# Altium schematic sheet urbanturbine.SchDoc(urbanturbine)
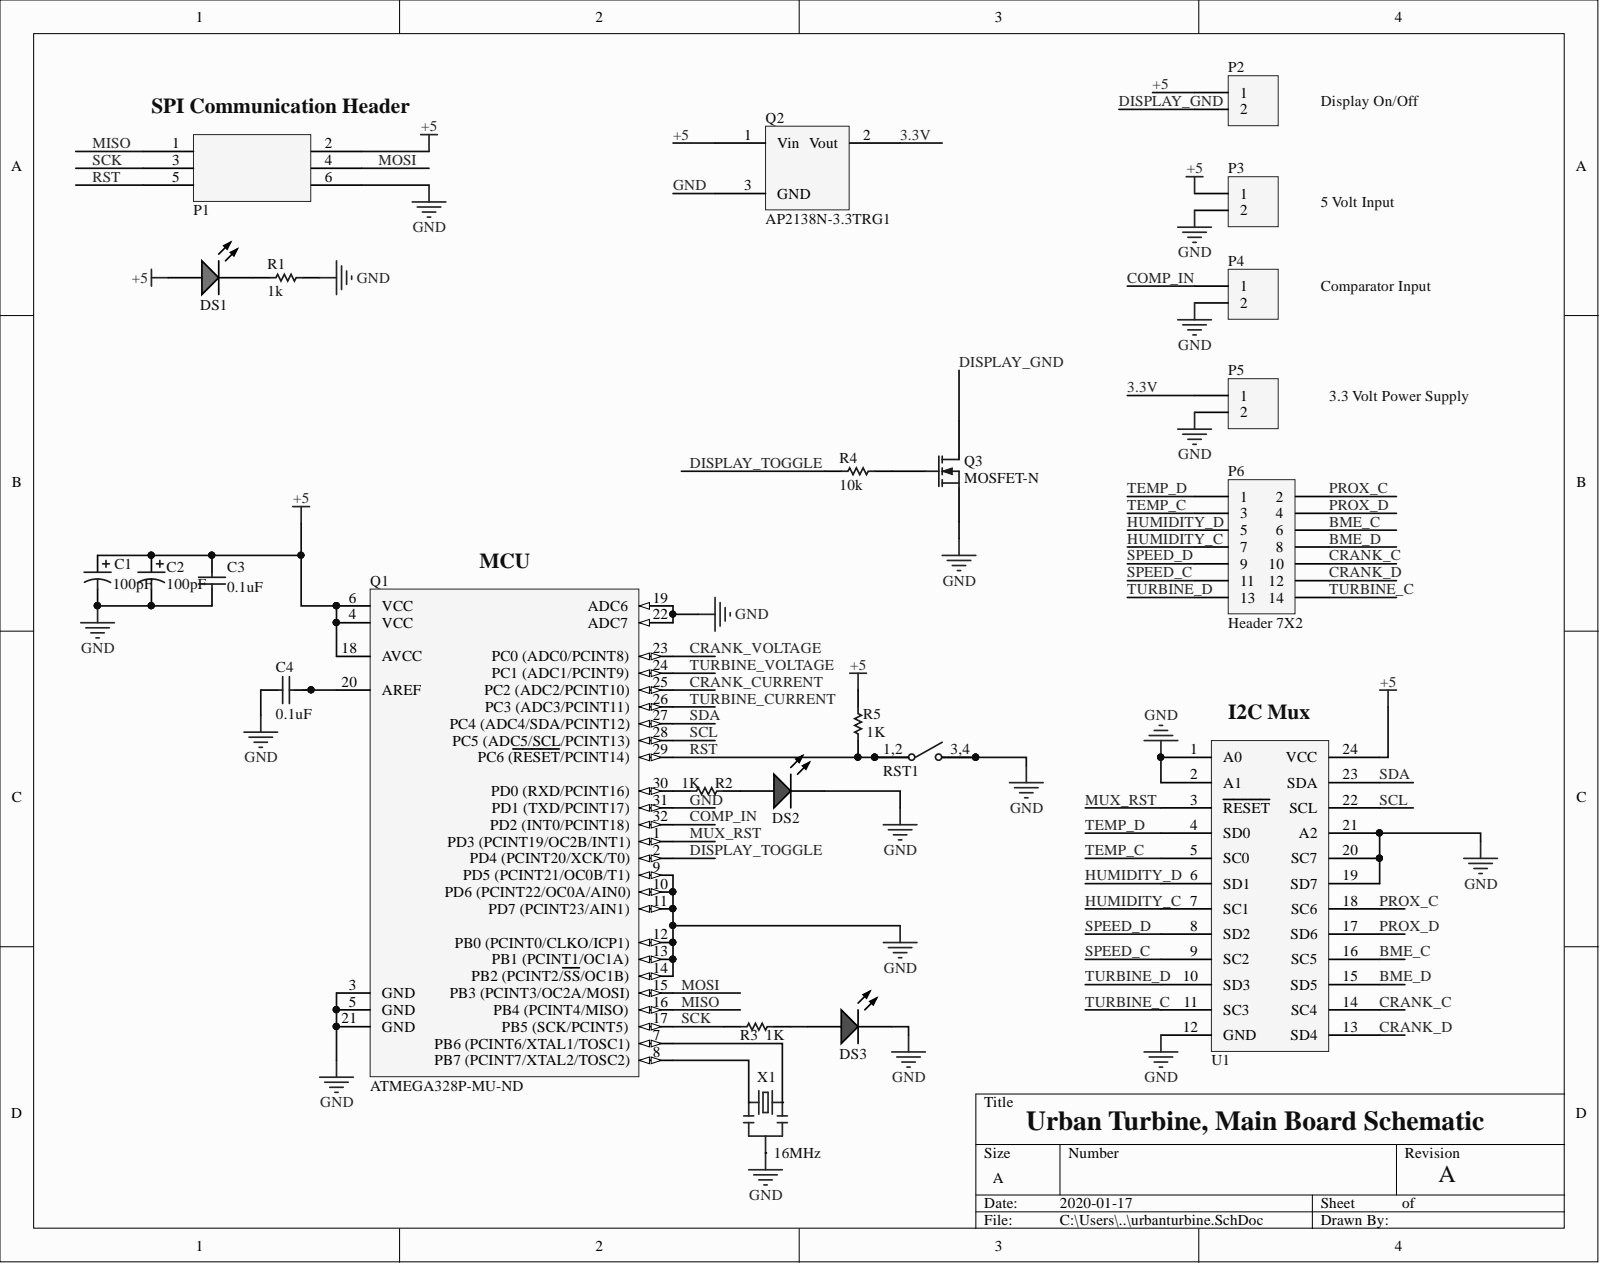
[diagram p0: urbanturbine.SchDoc]

## Parts
C1  value 100pF  [RAD-0.1]  (hidden comment: Cap Pol1)
C2  value 100pF  [RAD-0.1]  (hidden comment: Cap Pol1)
C3  value 0.1uF  [0402]  (hidden comment: C1005X7R1H104K050BB)
C4  value 0.1uF  [0402]  (hidden comment: C1005X7R1H104K050BB)
DS1  LTST-C191KGKT  [KING-LED0603-25-GREEN_V]  (value not drawn)
DS2  LTST-C191KRKT  [KING-LED0603-25-RED_V]  (value not drawn)
DS3  LTST-C191KRKT  [KING-LED0603-25-RED_V]  (value not drawn)
P1  M20-9980346  [2X3]  (value not drawn)
P2  (no value)  [HDR1X2]
P3  (no value)  [HDR1X2]
P4  (no value)  [HDR1X2]
P5  (no value)  [HDR1X2]
P6  Header 7X2  [HDR2X7]
Q1  ATMEGA328P-MU  Supplier Part Number 1: ATMEGA328P-MU-ND  [*DIL28]
Q2  AP2138N-3.3TRG1  [SOT23_M]
Q3  MOSFET-N  [E3]
R1  value 1k  [6-0805_M]  (hidden comment: RC0603JR-071KL)
R2  value 1K  [6-0805_M]  (hidden comment: Res1)
R3  value 1K  [6-0805_M]  (hidden comment: Res1)
R4  value 10k  [6-0805_M]  (hidden comment: ERJ-3EKF1002V)
R5  value 1K  [6-0805_M]  (hidden comment: Res1)
RST1  PTS645SH50SMTR92 LFS  [TACTILE SWITCH SMD]  (value not drawn)
U1  TCA9548A  [TCA9548A]  (value not drawn)
X1  16MHz  [RESONATOR-SMD]

## Nets
3.3V: P5.1 Q2.2
+5: C1.1 C2.1 C3.2 DS1.1 P1.2 P2.1 P3.1 Q1.4(VCC) Q1.6(VCC) Q1.18(AVCC) Q2.1 R5.1 U1.24(VCC)
BME_C: P6.6 U1.16(SC5)
BME_D: P6.8 U1.15(SD5)
COMP_IN: P4.1 Q1.32(PD2_(INT0/PCINT18))
CRANK_C: P6.10 U1.14(SC4)
CRANK_CURRENT: Q1.25
CRANK_D: P6.12 U1.13(SD4)
CRANK_VOLTAGE: Q1.23(PC0_(ADC0/PCINT8))
DISPLAY_GND: P2.2 Q3.1
DISPLAY_TOGGLE: Q1.2(PD4_(PCINT20/XCK/T0)) R4.2
GND: C1.2 C2.2 C3.1 C4.1 DS2.2 DS3.2 P1.6 P3.2 P4.2 P5.2 Q1.3(GND) Q1.5(GND) Q1.9(PD5_(PCINT21/OC0B/T1)) Q1.10(PD6_(PCINT22/OC0A/AIN0)) Q1.11(PD7_(PCINT23/AIN1)) Q1.12(PB0_(PCINT0/CLKO/ICP1)) Q1.13(PB1_(PCINT1/OC1A)) Q1.14(PB2_(PCINT2/SS/OC1B)) Q1.19(ADC6) Q1.21(GND) Q1.22(ADC7) Q1.31(PD1_(TXD/PCINT17)) Q2.3 Q3.3 R1.2 RST1.3 RST1.4 U1.1(A0) U1.2(A1) U1.12(GND) U1.19(SD7) U1.20(SC7) U1.21(A2) X1.2
HUMIDITY_C: P6.7 U1.7(SC1)
HUMIDITY_D: P6.5 U1.6(SD1)
MISO: P1.1 Q1.16(PB4_(PCINT4/MISO))
MOSI: P1.4 Q1.15
MUX_RST: Q1.1(PD3_(PCINT19/OC2B/INT1)) U1.3(RESET)
PROX_C: P6.2 U1.18(SC6)
PROX_D: P6.4 U1.17(SD6)
RST: P1.5 Q1.29(PC6_(RESET/PCINT14)) R5.2 RST1.1 RST1.2
SCK: P1.3 Q1.17(PB5_(SCK/PCINT5)) R3.1
SCL: Q1.28(PC5_(ADC5/SCL/PCINT13)) U1.22(SCL)
SDA: Q1.27(PC4_(ADC4/SDA/PCINT12)) U1.23(SDA)
SPEED_C: P6.11 U1.9(SC2)
SPEED_D: P6.9 U1.8(SD2)
TEMP_C: P6.3 U1.5(SC0)
TEMP_D: P6.1 U1.4(SD0)
TURBINE_C: P6.14 U1.11(SC3)
TURBINE_CURRENT: Q1.26(PC3_(ADC3/PCINT11))
TURBINE_D: P6.13 U1.10(SD3)
TURBINE_VOLTAGE: Q1.24(PC1_(ADC1/PCINT9))
NetC4_2: C4.2 Q1.20(AREF)
NetDS1_2: DS1.2 R1.1
NetDS2_1: DS2.1 R2.2
NetDS3_1: DS3.1 R3.2
NetQ1_7: Q1.7(PB6_(PCINT6/XTAL1/TOSC1)) X1.1
NetQ1_8: Q1.8(PB7_(PCINT7/XTAL2/TOSC2)) X1.3
NetQ1_30: Q1.30(PD0_(RXD/PCINT16)) R2.1
NetQ3_2: Q3.2 R4.1

## Library data of the parts
C1 C2 = Cap Pol1: Polarized Capacitor (Radial); Simulation: CAP; Signal Integrity: Cap Pol; Published: 8-Jun-2000; PackageReference: RB7.6-15; PackageDescription: Polarized Capacitor (Radial); 2 Leads; Library Name: Miscellaneous Devices.IntLib; LatestRevisionNote: Re-released for DXP Platform.; LatestRevisionDate: 17-Jul-2002; Component Kind: Standard; Publisher: Altium Limited
C3 C4 = C1005X7R1H104K050BB: CAP CER 0.1UF 50V 10% X7R 0402; Manufacturer: TDK Corporation; Capacitance: 0.1µF; Voltage - Rated: 50V; Tolerance: ±10%; Thickness (Max): 0.022" (0.55mm); Temperature Coefficient: X7R; Supplier Part Number 1: 445-5932-1-ND; Supplier 1: Digi-Key; Stock 1: 7100869; Size / Dimension: 0.039" L x 0.020" W (1.00mm x 0.50mm); RoHS: RoHS Compliant; Ratings: -; Pricing 1: 1=0.21, 10=0.14, 100=0.0664, 250=0.05592, 500=0.04684, 1000=0.03845, 5000=0.03251 (CAD); Packaging: Cut Tape (CT); Package / Case: 0402 (1005 Metric); Operating Temperature: -55°C ~ 125°C; Mounting Type: Surface Mount, MLCC; Manufacturer Part Number: C1005X7R1H104K050BB; Lead Style: -; Lead Spacing: -; Height - Seated (Max): -; Features: -; ComponentLink1URL: http://product.tdk.com/en/catalog/datasheets/mlcc_commercial_general_en.pdf; ComponentLink1Description: http://product.tdk.com/en/catalog/datasheets/mlcc_commercial_general_en.pdf; Component Kind: Standard; Category: Capacitors; Applications: General Purpose; Library Name: Jason_SCH Library.SchLib
DS1 = LTST-C191KGKT: LED GREEN CLEAR THIN 0603 SMD; Manufacturer: Lite-On Inc.; Wavelength - Peak: 574nm; Wavelength - Dominant: 571nm; Voltage - Forward (Vf) (Typ): 2V; Viewing Angle: 130°; Millicandela Rating: 35mcd; Lens Style/Size: Rectangle with Flat Top, 1.10mm x 0.80mm; Lens Color: Colorless; Current - Test: 20mA; Supplier Part Number 1: 160-1446-6-ND; Supplier 1: Digi-Key; Stock 1: 186242; Size / Dimension: 1.60mm L x 0.80mm W; RoHS: RoHS Compliant; Pricing 1: 1=0.42, 10=0.333, 100=0.1812, 250=0.1208, 500=0.10268, 1000=0.07853, 2500=0.07098 (CAD); Packaging: Digi-Reel®; Package / Case: 0603 (1608 Metric); Mounting Type: Surface Mount; Manufacturer Part Number: LTST-C191KGKT; Lens Transparency: Clear; Height (Max): 0.55mm; ComponentLink1URL: http://optoelectronics.liteon.com/upload/download/DS22-2000-228/S_110_LTST-C191KGKT.pdf; ComponentLink1Description: http://optoelectronics.liteon.com/upload/download/DS22-2000-228/S_110_LTST-C191KGKT.pdf; Component Kind: Standard; Color: Green; Category: Optoelectronics; Library Name: Jason_SCH Library.SchLib
DS2 DS3 = LTST-C191KRKT: LED SUPER RED CLR THIN 0603 SMD; Manufacturer: Lite-On Inc.; Wavelength - Peak: 639nm; Wavelength - Dominant: 631nm; Voltage - Forward (Vf) (Typ): 2V; Viewing Angle: 130°; Millicandela Rating: 54mcd; Lens Transparency: Clear; Lens Style/Size: Rectangle with Flat Top, 1.10mm x 0.80mm; Lens Color: Colorless; Current - Test: 20mA; Supplier Part Number 1: 160-1447-1-ND; Supplier 1: Digi-Key; Stock 1: 239192; Size / Dimension: 1.60mm L x 0.80mm W; RoHS: RoHS Compliant; Pricing 1: 1=0.42, 10=0.333, 100=0.1812, 250=0.1208, 500=0.10268, 1000=0.07853, 2500=0.07098 (CAD); Packaging: Cut Tape (CT); Package / Case: 0603 (1608 Metric); Mounting Type: Surface Mount; Manufacturer Part Number: LTST-C191KRKT; Height (Max): 0.55mm; ComponentLink1URL: http://optoelectronics.liteon.com/upload/download/DS22-2000-223/S_110_LTST-C191KRKT.pdf; ComponentLink1Description: http://optoelectronics.liteon.com/upload/download/DS22-2000-223/S_110_LTST-C191KRKT.pdf; Component Kind: Standard; Color: Red; Category: Optoelectronics; Library Name: Jason_SCH Library.SchLib
P1 = M20-9980346: DIL VERTICAL PC TAIL PIN HEADER; Manufacturer: Harwin Inc.; Row Spacing: 0.100" (2.54mm); Number of Positions Loaded: All; Number of Positions: 6; Connector Type: Header, Unshrouded, Breakaway; Termination: Solder; Supplier Part Number 1: 952-2121-ND; Supplier 1: Digi-Key; Stock 1: 14297; RoHS: RoHS Compliant; Pricing 1: 1=0.32, 10=0.299, 25=0.2552, 50=0.2232, 100=0.2072, 250=0.18336, 500=0.17534, 1000=0.14346, 2500=0.13151 (CAD); Pitch: 0.100" (2.54mm); Packaging: Bulk; Number of Rows: 2; Mounting Type: Through Hole; Manufacturer Part Number: M20-9980346; Library Name: Main SCH Library.SchLib; Features: -; Fastening Type: -; Contact Type: Male Pin; Contact Mating Length: 0.240" (6.10mm); Contact Finish Thickness: -; Contact Finish: Tin; ComponentLink1URL: http://cdn.harwin.com/pdfs/M20-998.pdf; ComponentLink1Description: http://cdn.harwin.com/pdfs/M20-998.pdf; Component Kind: Standard; Color: Black; Category: Connectors, Interconnects
P2-P5 = Header 2: Header, 2-Pin; Signal Integrity: Connector; Library Name: Miscellaneous Connectors.IntLib; LatestRevisionNote: Re-released for DXP Platform.; LatestRevisionDate: 17-Jul-2002; Component Kind: Standard; Publisher: Altium Limited
P6 = Header 7X2: Header, 7-Pin, Dual row; Signal Integrity: Connector; Library Name: Miscellaneous Connectors.IntLib; LatestRevisionNote: Re-released for DXP Platform.; LatestRevisionDate: 17-Jul-2002; Component Kind: Standard; Publisher: Altium Limited
Q1 = ATMEGA328P-MU: IC MCU 8BIT 32KB FLASH 32QFN; Manufacturer: Atmel; Voltage - Supply (Vcc/Vdd): 1.8 V ~ 5.5 V; Speed: 20MHz; RAM Size: 2K x 8; Program Memory Size: 32KB (16K x 16); Peripherals: Brown-out Detect/Reset, POR, PWM, WDT; Oscillator Type: Internal; Number of I/O: 23; EEPROM Size: 1K x 8; Data Converters: A/D 8x10b; Core Size: 8-Bit; Core Processor: AVR; Connectivity: I²C, SPI, UART/USART; Supplier Device Package: 32-VQFN (5x5); Supplier 1: Digi-Key; Stock 1: 70195; RoHS: RoHS Compliant; Program Memory Type: FLASH; Pricing 1: 1=5.01, 10=4.465, 100=3.6608, 250=3.30364, 500=2.96432, 1000=2.50003 (CAD); Packaging: Tray; Package / Case: 32-VFQFN Exposed Pad; Operating Temperature: -40°C ~ 85°C; Manufacturer Part Number: ATMEGA328P-MU; ComponentLink1URL: http://www.atmel.com/images/atmel-8271-8-bit-avr-microcontroller-atmega48a-48pa-88a-88pa-168a-168pa-328-328p_datasheet_summary.pdf; ComponentLink1Description: http://www.atmel.com/images/atmel-8271-8-bit-avr-microcontroller-atmega48a-48pa-88a-88pa-168a-168pa-328-328p_datasheet_summary.pdf; Component Kind: Standard; Category: Integrated Circuits (ICs); Library Name: Jason_SCH Library.SchLib
Q2 = AP2138N-3.3TRG1: IC REG LINEAR 3.3V 250MA SOT23-3; Component Kind: Standard
Q3 = MOSFET-N: N-Channel MOSFET; Simulation: NMOS; Published: 8-Jun-2000; PackageVersion: 1995/1996; PackageReference: E3; PackageDescription: TO, Flat Index; 3 In-Line, Axial Leads; Body Dia. 4.6mm; Lead Dia. 0.5 mm (max); LatestRevisionNote: Re-released for DXP Platform.; LatestRevisionDate: 17-Jul-2002; Component Kind: Standard; Publisher: Altium Limited
R1 = RC0603JR-071KL: RES SMD 1K OHM 5% 1/10W 0603; Manufacturer: Yageo; Temperature Coefficient: ±100ppm/°C; Power (Watts): 0.1W, 1/10W; Tolerance: ±5%; Supplier Part Number 1: 311-1.0KGRCT-ND; Supplier Device Package: 0603; Supplier 1: Digi-Key; Stock 1: 1746734; Size / Dimension: 0.063" L x 0.031" W (1.60mm x 0.80mm); RoHS: RoHS Compliant; Resistance (Ohms): 1k; Pricing 1: 1=0.15, 10=0.016, 25=0.012, 100=0.0066, 250=0.005, 500=0.00402, 1000=0.00295, 2500=0.00256 (CAD); Packaging: Cut Tape (CT); Package / Case: 0603 (1608 Metric); Operating Temperature: -55°C ~ 155°C; Number of Terminations: 2; Manufacturer Part Number: RC0603JR-071KL; Height: 0.022" (0.55mm); Features: Moisture Resistant; Composition: Thick Film; ComponentLink1URL: http://www.yageo.com/NewPortal/yageodocoutput?fileName=/pdf/R-Chip/PYu-RC_Group_51_RoHS_L_3.pdf; ComponentLink1Description: http://www.yageo.com/NewPortal/yageodocoutput?fileName=/pdf/R-Chip/PYu-RC_Group_51_RoHS_L_3.pdf; Component Kind: Standard; Category: Resistors; Library Name: Jason_SCH Library.SchLib
R2 R3 R5 = Res1: Resistor; Simulation: RESISTOR; Signal Integrity: Res; Published: 8-Jun-2000; PackageReference: AXIAL-0.3; PackageDescription: Axial Device, Thru-Hole; 2 Leads; 0.3 in Pin Spacing; Library Name: Miscellaneous Devices.IntLib; LatestRevisionNote: Re-released for DXP Platform.; LatestRevisionDate: 17-Jul-2002; Component Kind: Standard; Publisher: Altium Limited
R4 = ERJ-3EKF1002V: RES SMD 10K OHM 1% 1/10W 0603; Manufacturer: Panasonic Electronic Components; Temperature Coefficient: ±100ppm/°C; Power (Watts): 0.1W, 1/10W; Tolerance: ±1%; Supplier Part Number 1: P10.0KHCT-ND; Supplier Device Package: 0603; Supplier 1: Digi-Key; Stock 1: 5981773; Size / Dimension: 0.063" L x 0.031" W (1.60mm x 0.80mm); RoHS: RoHS Compliant; Resistance (Ohms): 10k; Pricing 1: 1=0.15, 50=0.017, 100=0.0125, 250=0.0096, 500=0.00766, 1000=0.00564 (CAD); Packaging: Cut Tape (CT); Package / Case: 0603 (1608 Metric); Operating Temperature: -55°C ~ 155°C; Number of Terminations: 2; Manufacturer Part Number: ERJ-3EKF1002V; Height: 0.022" (0.55mm); Features: Automotive AEC-Q200; Composition: Thick Film; ComponentLink1URL: http://industrial.panasonic.com/www-cgi/jvcr13pz.cgi?E+PZ+3+AOA0002+ERJ3EKF1002V+7+WW; ComponentLink1Description: http://industrial.panasonic.com/www-cgi/jvcr13pz.cgi?E+PZ+3+AOA0002+ERJ3EKF1002V+7+WW; Component Kind: Standard; Category: Resistors
RST1 = PTS645SH50SMTR92 LFS: SWITCH TACTILE SPST-NO 0.05A 12V; Manufacturer: C&K Components; Switch Function: Off-Mom; Outline: 6.00mm x 6.00mm; Operating Force: 200gf; Mechanical Life: 100,000 Cycles; Illumination: Non-Illuminated; Contact Rating @ Voltage: 0.05A @ 12VDC; Actuator Type: Standard; Actuator Orientation: Top Actuated; Actuator Material: Polyamide (PA66), Nylon 6/6; Actuator Height off PCB, from Pins: 5.00mm; Termination Style: Gull Wing; Switch Travel: -; Supplier Part Number 1: CKN9085CT-ND; Supplier 1: Digi-Key; Stock 1: 49163; RoHS: RoHS Compliant; Pricing 1: 1=0.43, 10=0.418, 25=0.404, 50=0.3892, 100=0.3675, 250=0.34592, 500=0.3315 (CAD); Packaging: Cut Tape (CT); Operating Temperature: -20°C ~ 60°C; Mounting Type: Surface Mount; Manufacturer Part Number: PTS645SH50SMTR92 LFS; Ingress Protection: -; Illumination Voltage (Nominal): -; Illumination Type, Color: -; Features: -; ComponentLink1URL: http://www.ck-components.com/14409/pts645_25apr13.pdf/; ComponentLink1Description: http://www.ck-components.com/14409/pts645_25apr13.pdf/; Component Kind: Standard; Circuit: SPST-NO; Category: Switches; Library Name: Jason_SCH Library.SchLib
U1 = TCA9548A: IIC multiplexor; Component Kind: Standard; Library Name: urbanturbine.SchLib
X1 = CSTNE16M0VH3C000R0: CER RESONATOR 16MHZ SMD; Manufacturer Part Number: CSTCE10M0G55Z-R0; Manufacturer: Murata Electronics North America; Frequency Tolerance: ±0.5%; Frequency Stability: ±0.2%; Frequency: 10MHz; Capacitance: 33pF; Type: Ceramic; Supplier Part Number 1: 490-4715-1-ND; Supplier 1: Digi-Key; Stock 1: 14995; Size / Dimension: 0.126" L x 0.051" W (3.20mm x 1.30mm); RoHS: RoHS Compliant; Pricing 1: 1=0.69, 10=0.573, 50=0.5166, 100=0.4596, 500=0.43548, 1000=0.36763 (CAD); Packaging: Cut Tape (CT); Package / Case: 3-SMD, Non-Standard; Operating Temperature: -40°C ~ 125°C; Mounting Type: Surface Mount; Impedance: -; Height: 0.031" (0.80mm); Features: Built in Capacitor; ComponentLink1URL: http://www.murata.com/~/media/webrenewal/support/library/catalog/products/timingdevice/ceralock/p16e.ashx; ComponentLink1Description: http://www.murata.com/~/media/webrenewal/support/library/catalog/products/timingdevice/ceralock/p16e.ashx; Component Kind: Standard; Category: Crystals and Oscillators; Library Name: Jason_SCH Library.SchLib
